# Altium schematic sheet Power_Supply.SchDoc
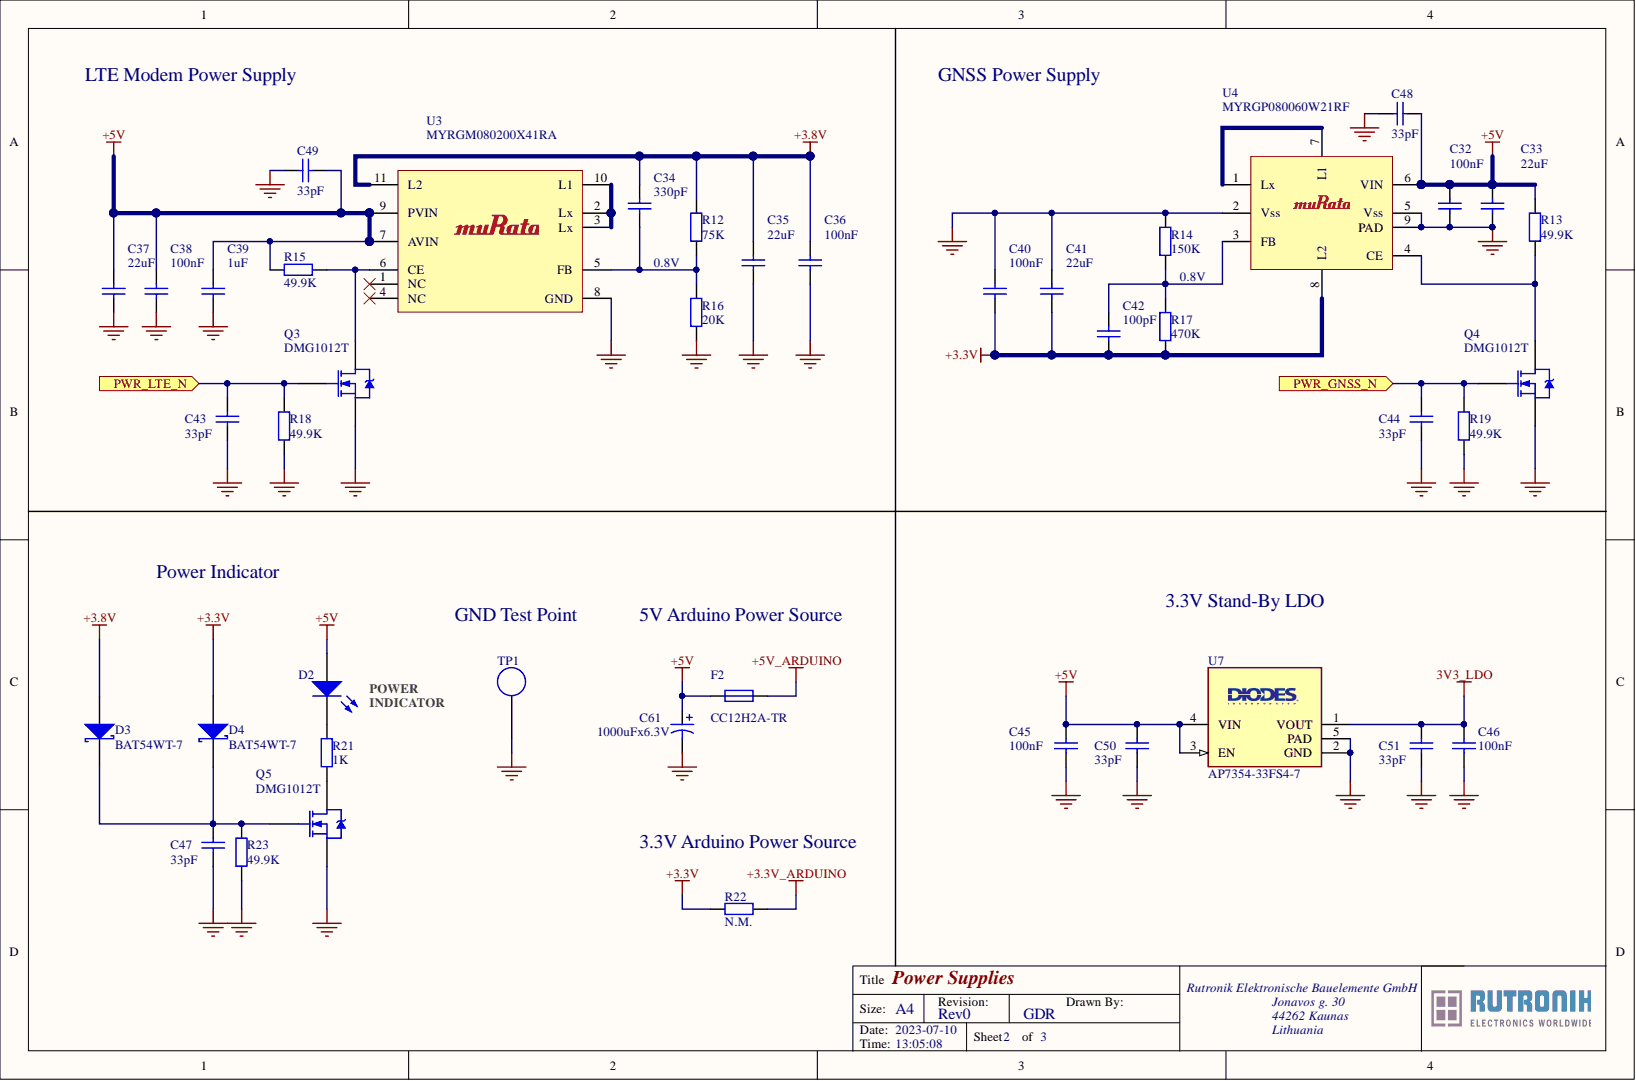
[diagram p0: Power_Supply.SchDoc]

## Parts
C32  value 100nF  [C0402]  (hidden comment: Cap Semi)
C33  value 22uF  [C0805]  (hidden comment: Cap Semi)
C34  value 330pF  [C0402]  (hidden comment: Cap Semi)
C35  value 22uF  [C0805]  (hidden comment: Cap Semi)
C36  value 100nF  [C0402]  (hidden comment: Cap Semi)
C37  value 22uF  [C0805]  (hidden comment: Cap Semi)
C38  value 100nF  [C0402]  (hidden comment: Cap Semi)
C39  value 1uF  [C0402]  (hidden comment: Cap Semi)
C40  value 100nF  [C0402]  (hidden comment: Cap Semi)
C41  value 22uF  [C0805]  (hidden comment: Cap Semi)
C42  value 100pF  [C0402]  (hidden comment: Cap Semi)
C43  value 33pF  [C0402]  (hidden comment: Cap Semi)
C44  value 33pF  [C0402]  (hidden comment: Cap Semi)
C45  value 100nF  [C0402]  (hidden comment: Cap Semi)
C46  value 100nF  [C0402]  (hidden comment: Cap Semi)
C47  value 33pF  [C0402]  (hidden comment: Cap Semi)
C48  value 33pF  [C0402]  (hidden comment: Cap Semi)
C49  value 33pF  [C0402]  (hidden comment: Cap Semi)
C50  value 33pF  [C0402]  (hidden comment: Cap Semi)
C51  value 33pF  [C0402]  (hidden comment: Cap Semi)
C61  value 1000uFx6.3V  [7361-38]  (hidden comment: electrolytic capacitor)
D2  LED  [KG_DELLS_GREEN]  (value not drawn)
D3  BAT54WT-7  [SOD-523]
D4  BAT54WT-7  [SOD-523]
F2  CC12H2A-TR  [FUSE1206]
Q3  DMG1012T  [SOT-523_custom]
Q4  DMG1012T  [SOT-523_custom]
Q5  DMG1012T  [SOT-523_custom]
R12  value 75K  [R0402]  (hidden comment: Res2)
R13  value 49.9K  [R0402]  (hidden comment: Res2)
R14  value 150K  [R0402]  (hidden comment: Res2)
R15  value 49.9K  [R0402]  (hidden comment: Res2)
R16  value 20K  [R0402]  (hidden comment: Res2)
R17  value 470K  [R0402]  (hidden comment: Res2)
R18  value 49.9K  [R0402]  (hidden comment: Res2)
R19  value 49.9K  [R0402]  (hidden comment: Res2)
R21  value 1K  [R0402]  (hidden comment: Res2)
R22  value N.M.  [R1206]  (hidden comment: Res2)  (no BOM)
R23  value 49.9K  [R0402]  (hidden comment: Res2)
TP1  GND Test Point  [5019_keystone]
U3  MYRGM080200X41RA  [MYRGM080200]
U4  MYRGP080060W21RF  [MYRGP080060]
U7  AP7354-33FS4-7  [X2-DFN1010-4]

## Ports
PWR_GNSS_N, PWR_LTE_N

## Nets
3V3_LDO: C46.1 C51.1 U7.1(VOUT)
+3.3V: C40.2 C41.1 C42.2 D4.1 R17.2 R22.2 U4.8(L2)
+3.3V_ARDUINO: R22.1
+3.8V: C34.1 C35.2 C36.1 D3.1 R12.2 U3.11(L2)
+5V: C32.1 C33.2 C37.2 C38.1 C39.1 C45.1 C48.2 C49.2 C50.1 C61.1 D2.1 F2.2 R13.1 R15.1 U3.7(AVIN) U3.9(PVIN) U4.6(VIN) U7.3(EN) U7.4(VIN)
+5V_ARDUINO: F2.1
GND: C32.2 C33.1 C35.1 C36.2 C37.1 C38.2 C39.2 C40.1 C41.2 C43.2 C44.2 C45.2 C46.2 C47.2 C48.1 C49.1 C50.2 C51.2 C61.2 Q3.3 Q4.3 Q5.3 R14.1 R16.1 R18.2 R19.2 R23.2 TP1.1 U3.8(GND) U4.2(Vss) U4.5(Vss) U4.9(PAD) U7.2(GND) U7.5(PAD)
PWR_GNSS_N: C44.1 Q4.1 R19.1 ; port PWR_GNSS_N
PWR_LTE_N: C43.1 Q3.1 R18.1 ; port PWR_LTE_N
NetC34_2: C34.2 R12.1 R16.2 U3.5(FB)
NetC42_1: C42.1 R14.2 R17.1 U4.3(FB)
NetC47_1: C47.1 D3.2 D4.2 Q5.1 R23.1
NetD2_2: D2.2 R21.2
NetQ3_2: Q3.2 R15.2 U3.6(CE)
NetQ4_2: Q4.2 R13.2 U4.4(CE)
NetQ5_2: Q5.2 R21.1
NetU3_2: U3.2(Lx) U3.3(Lx) U3.10(L1)
NetU4_1: U4.1(Lx) U4.7(L1)

Not connected: U3 1(NC) 4(NC)

## Library data of the parts
C32 C36 C38 C40 C45 C46 = Cap Semi: Capacitor (Semiconductor SIM Model); Manufacturer: TDK; Manufacturer Part No.: CGA2B3X7R1H104K050BB; Part Description: Ceramic Capacitor; Specification: X7R, 50V, 10%; Component Kind: Standard; Library Name: Miscellaneous Devices.IntLib; Link: https://www.rutronik24.com/product/tdk/cga2b3x7r1h104k050bb/15441483.html; Signal Integrity: Cap Semi; Simulation: CAP; Supplier: Rutronik; Supplier part number: KKA1277
C33 C35 C37 C41 = Cap Semi: Capacitor (Semiconductor SIM Model); Manufacturer: Samsung EM; Manufacturer Part No.: CL21A226KQQNNNG; Part Description: Ceramic Capacitor; Specification: X5R, 6.3V, 10%; Component Kind: Standard; Library Name: Miscellaneous Devices.IntLib; Link: https://www.rutronik24.com/product/samsung+em/cl21a226kqqnnng/32257.html; Signal Integrity: Cap Semi; Simulation: CAP; Supplier: Rutronik; Supplier part number: KKS2632
C34 C42 = Cap Semi: Capacitor (Semiconductor SIM Model); Part Description: Ceramic Capacitor; Specification: NP0, 50V, 5%; Component Kind: Standard; Library Name: Miscellaneous Devices.IntLib; Signal Integrity: Cap Semi; Simulation: CAP; Supplier: Rutronik
C39 = Cap Semi: Capacitor (Semiconductor SIM Model); Manufacturer: AVX; Manufacturer Part No.: 04026C105K4T2A; Part Description: Ceramic Capacitor; Specification: X7R, 6.3V, 10%; Component Kind: Standard; Library Name: Miscellaneous Devices.IntLib; Link: https://www.rutronik24.com/product/avx/04026c105k4t2a/17188196.html; Signal Integrity: Cap Semi; Simulation: CAP; Supplier: Rutronik; Supplier part number: KKA1598
C43 C44 C47-C51 = Cap Semi: Capacitor (Semiconductor SIM Model); Manufacturer: AVX; Manufacturer Part No.: 04025A330JAT2A; Part Description: Ceramic Capacitor; Specification: NP0, 50V, 5%; Component Kind: Standard; Library Name: Miscellaneous Devices.IntLib; Link: https://www.rutronik24.com/product/avx/04025a330jat2a/3692730.html; Signal Integrity: Cap Semi; Simulation: CAP; Supplier: Rutronik; Supplier part number: KKK13594
C61 = Cap Pol1: Manufacturer: AVX; Manufacturer Part No.: TPSV108M006R0050; Part Description: Tantalum Capacitor; Specification: 6,3V 20%; Component Kind: Standard; Library Name: Miscellaneous Devices.IntLib; Link: https://www.rutronik24.com/product/avx/tpsv108m006r0050/11647835.html; Signal Integrity: Cap Pol; Simulation: CAP; Supplier: Rutronik; Supplier part number: TPSV108M006R0050
D2 = LED2: Typical SMD LED; Manufacturer: OSRAM; Manufacturer Part No.: KGDELLS1.22-JGKH-24-0-2-S; Part Description: TOPLED® E1608; Specification: GREEN, 20mA; Value: KGDELLS1.22-JGKH-24-0-2-S; Component Kind: Standard; Library Name: Miscellaneous Devices.IntLib; Link: https://www.rutronik24.com/search-result/qs:KG%20DELLS1.22/reset:0; Simulation: LED2; Supplier: Rutronik; Supplier part number: LEDATV1791
D3 D4 = D Schottky: Schottky Diode; Manufacturer: Diodes Inc.; Part Description: Small signal Schottky diode; Specification: 30V, 0.1A; Component Kind: Standard; Library Name: Miscellaneous Devices.IntLib; Link: https://www.rutronik24.com/product/diodes+inc/bat54wt-7/11465617.html; Manufacturer Part No.: BAT54WT-7; Signal Integrity: D Schottky; Simulation: SKYDIODE; Supplier: Rutronik; Supplier part number: DSKY5741; Value: BAT54WT-7
F2 = Fuse: Manufacturer: EATON; Part Description: High I²t Chip fuse; Specification: 63V, 2A; Component Kind: Standard; Description: Fuse; Library Name: DevKit_STM32L5.SCHLIB; Link: https://www.rutronik24.com/search-result/qs:CC12H2A-TR/reset:0; Manufacturer Part No.: CC12H2A-TR; Simulation: FUSE; Supplier: Rutronik; Supplier part number: FUSE10755; Value: CC12H2A-TR
Q3-Q5 = NMOS-2: N-Channel Power MOSFET; Manufacturer: Diodes Inc.; Manufacturer Part No.: DMG1012T-7; Part Description: N-Channel MOSFET; Specification: 20V, 0.63A; Value: DMG1012T-7; Component Kind: Standard; Library Name: Miscellaneous Devices.IntLib; Link: https://www.rutronik24.com/product/diodes+inc/dmg1012t-7/5168665.html; Simulation: IRF1010; Supplier: Rutronik; Supplier part number: TMOSS6623
R12 R14 R16 R17 = Res2: Part Description: Thick Film Resistor; Specification: 5% 0,063W; Component Kind: Standard; Library Name: Miscellaneous Devices.IntLib; Signal Integrity: Res; Simulation: RESISTOR; Supplier: Rutronik
R13 R15 R18 R19 R23 = Res2: Manufacturer: Yageo; Manufacturer Part No.: RC0201FR-0749K9L; Part Description: Thick Film Resistor; Specification: 1% 0,05W; Component Kind: Standard; Library Name: Miscellaneous Devices.IntLib; Link: https://www.rutronik24.com/category-type/standard-chip-resistor/rchip-0201-1/move:0/package:0201/qs:30K%200201/resistor-value:49%252C9K; Signal Integrity: Res; Simulation: RESISTOR; Supplier: Rutronik; Supplier part number: WRC55619
R21 = Res2: Manufacturer: Yageo; Manufacturer Part No.: RC0201JR-071KL; Part Description: Thick Film Resistor; Specification: 5% 0,05W; Component Kind: Standard; Library Name: Miscellaneous Devices.IntLib; Link: https://www.rutronik24.com/product/yageo/rc0201jr-071kl/58401.html; Signal Integrity: Res; Simulation: RESISTOR; Supplier: Rutronik; Supplier part number: WRC50692
R22 = Res2: Part Description: Thick Film Resistor; Specification: 1% 0,25W; Library Name: Miscellaneous Devices.IntLib; Signal Integrity: Res; Simulation: RESISTOR; Supplier: Rutronik
TP1 = 5019: SMD Test Point; Manufacturer: Keystone Electronics; Manufacturer Part No.: P/N5019; Part Description: SMT Test Point; Specification: 3.81*2.03; Value: P/N5019; Component Kind: Standard; Library Name: test_point.SchLib; Link: https://www.rutronik24.com/product/keystone/5019/7028403.html; Supplier: Rutronik; Supplier part number: BAT4950
U3 = MYRGM080200X41RA: 2.0A Inductor Built-in Step-Down DC/DC Converter; Component Kind: Standard; Library Name: MYRGM080200X41RA.SchLib
U4 = MYRGP080060W21RF: Inductor Built-in Step-Down “mini DC/DC” Converter; Component Kind: Standard; Library Name: MYRGP080060W21RF.SchLib
U7 = AP7354-33FS4-7: 150mA Ultra-Low Quiescent Current LDO with Enable; Component Kind: Standard; Library Name: AP7354-33FS4-7.SchLib
